# Altium schematic sheet SD2_and_Intentional_State.SchDoc(SD2_and_Intentional_State)
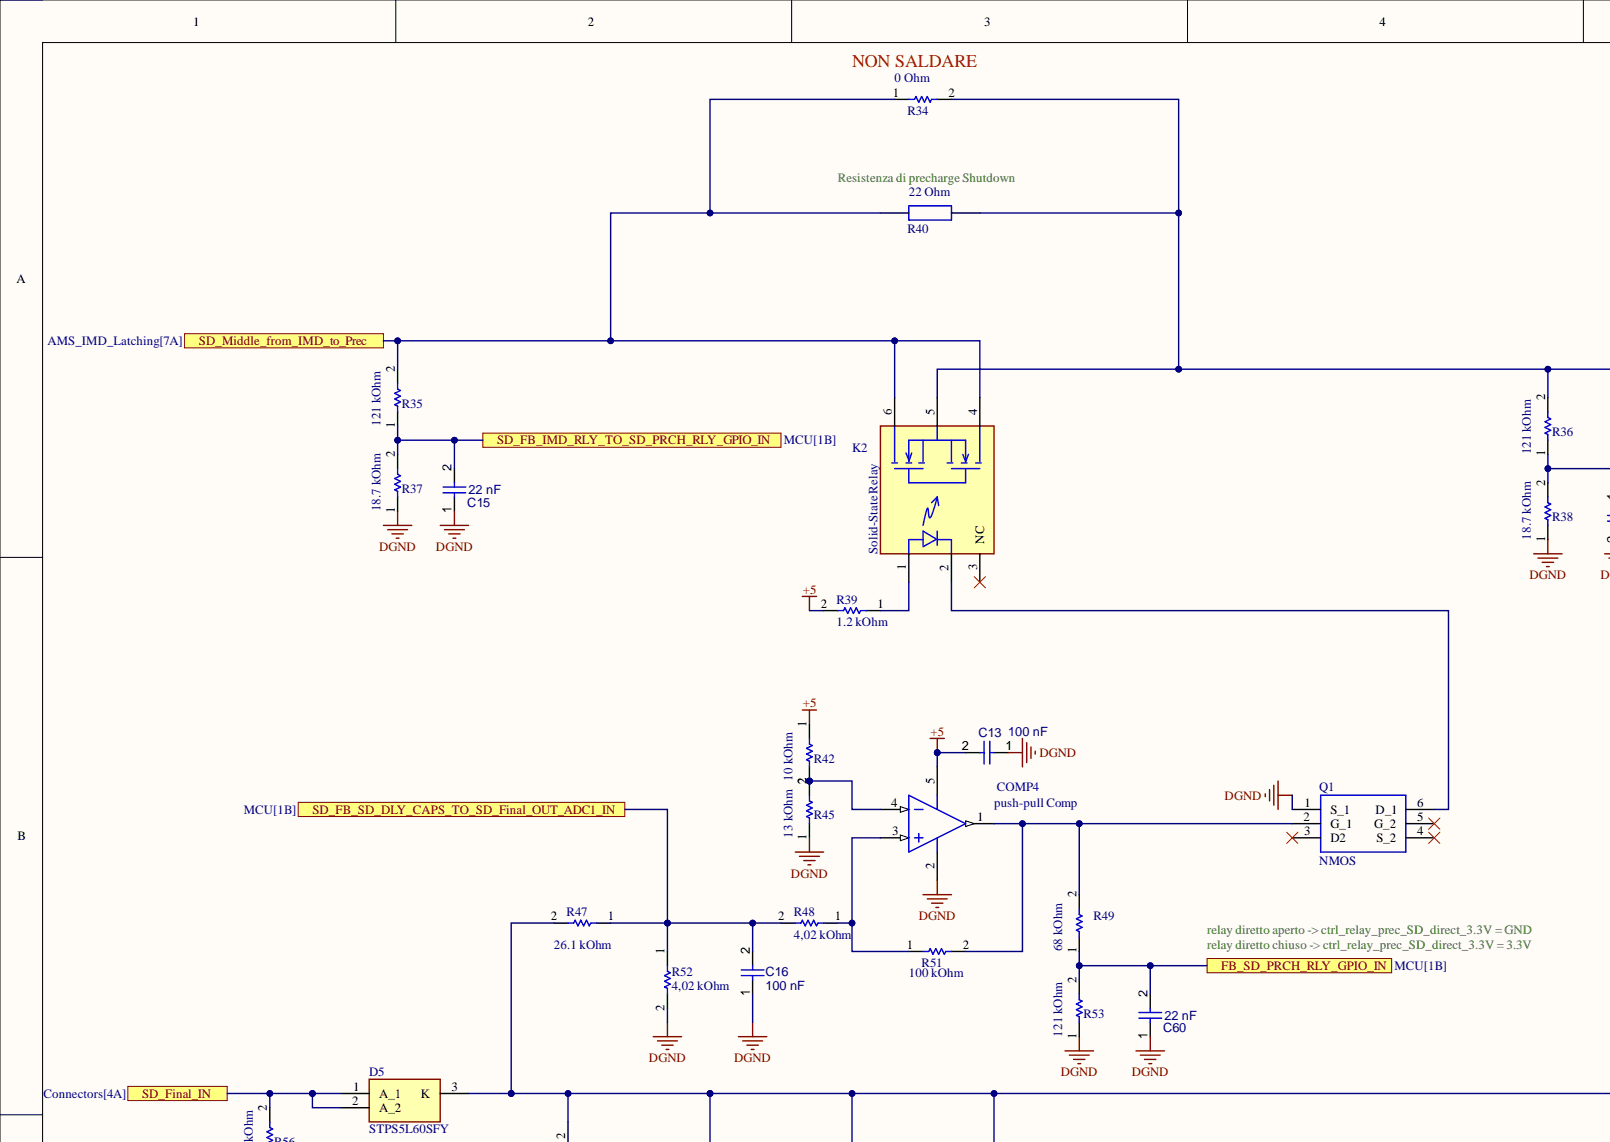
[diagram p0: SD2_and_Intentional_State.SchDoc, top left]
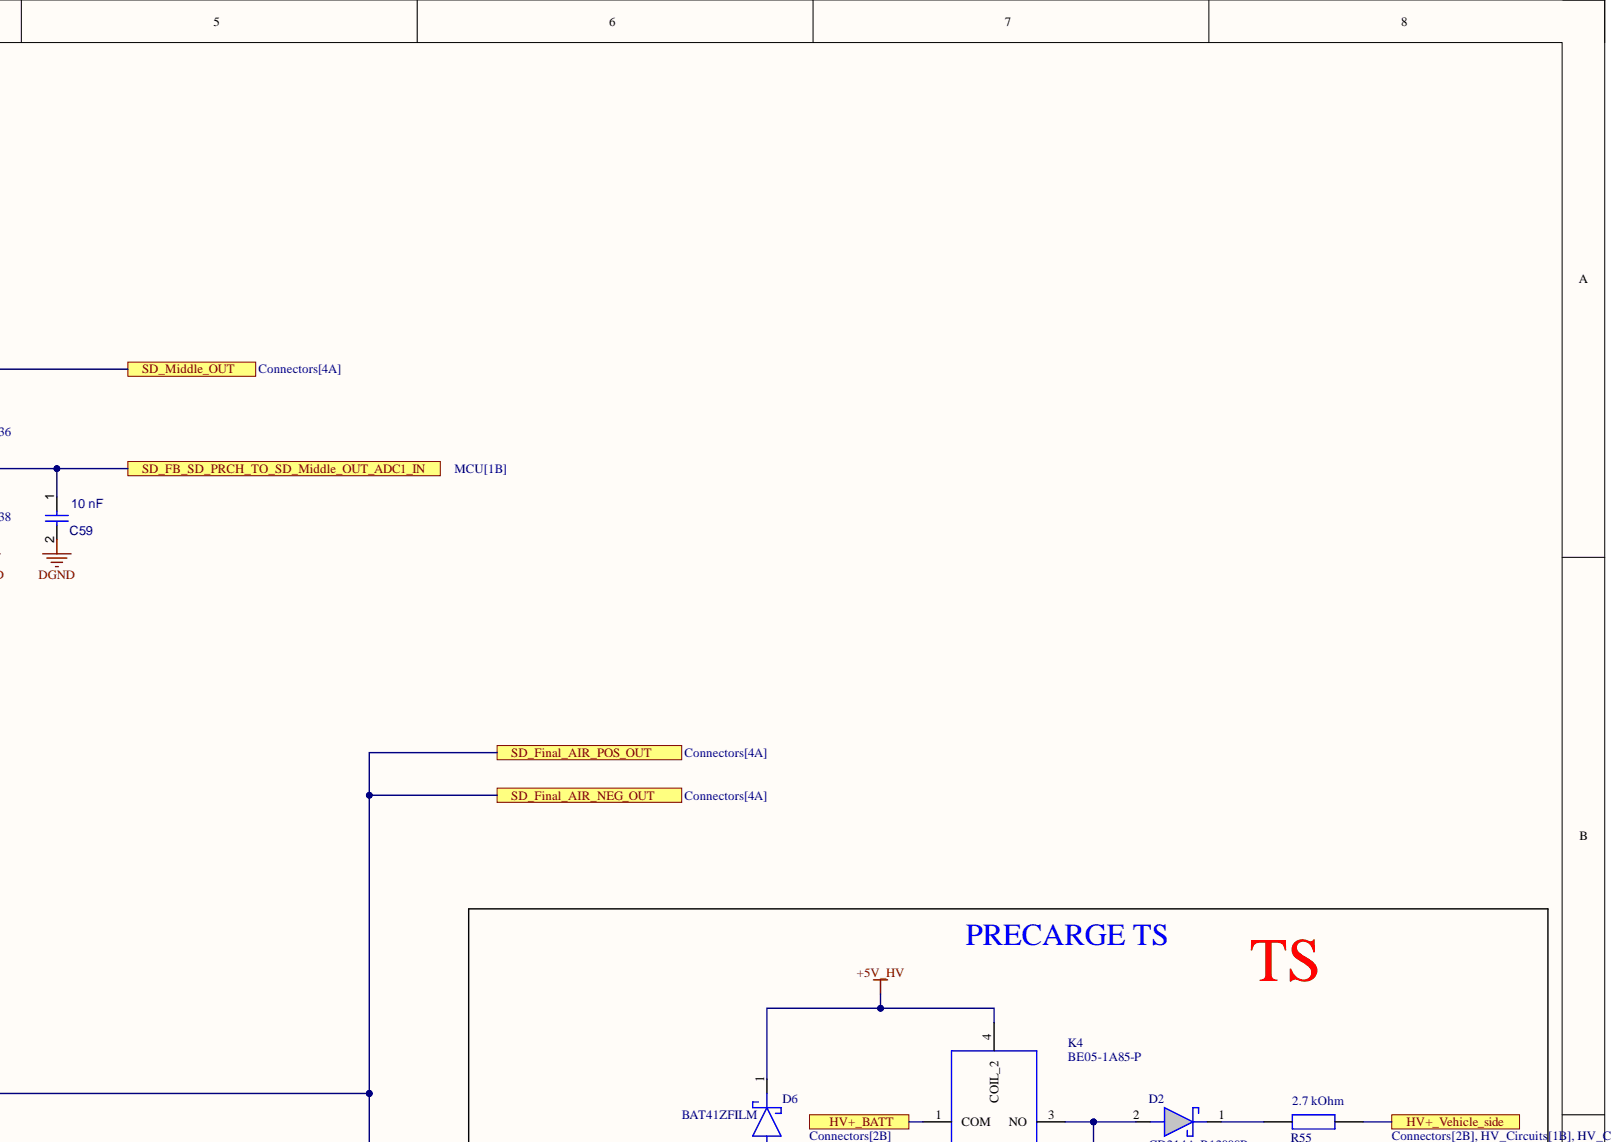
[diagram p1: SD2_and_Intentional_State.SchDoc, top right]
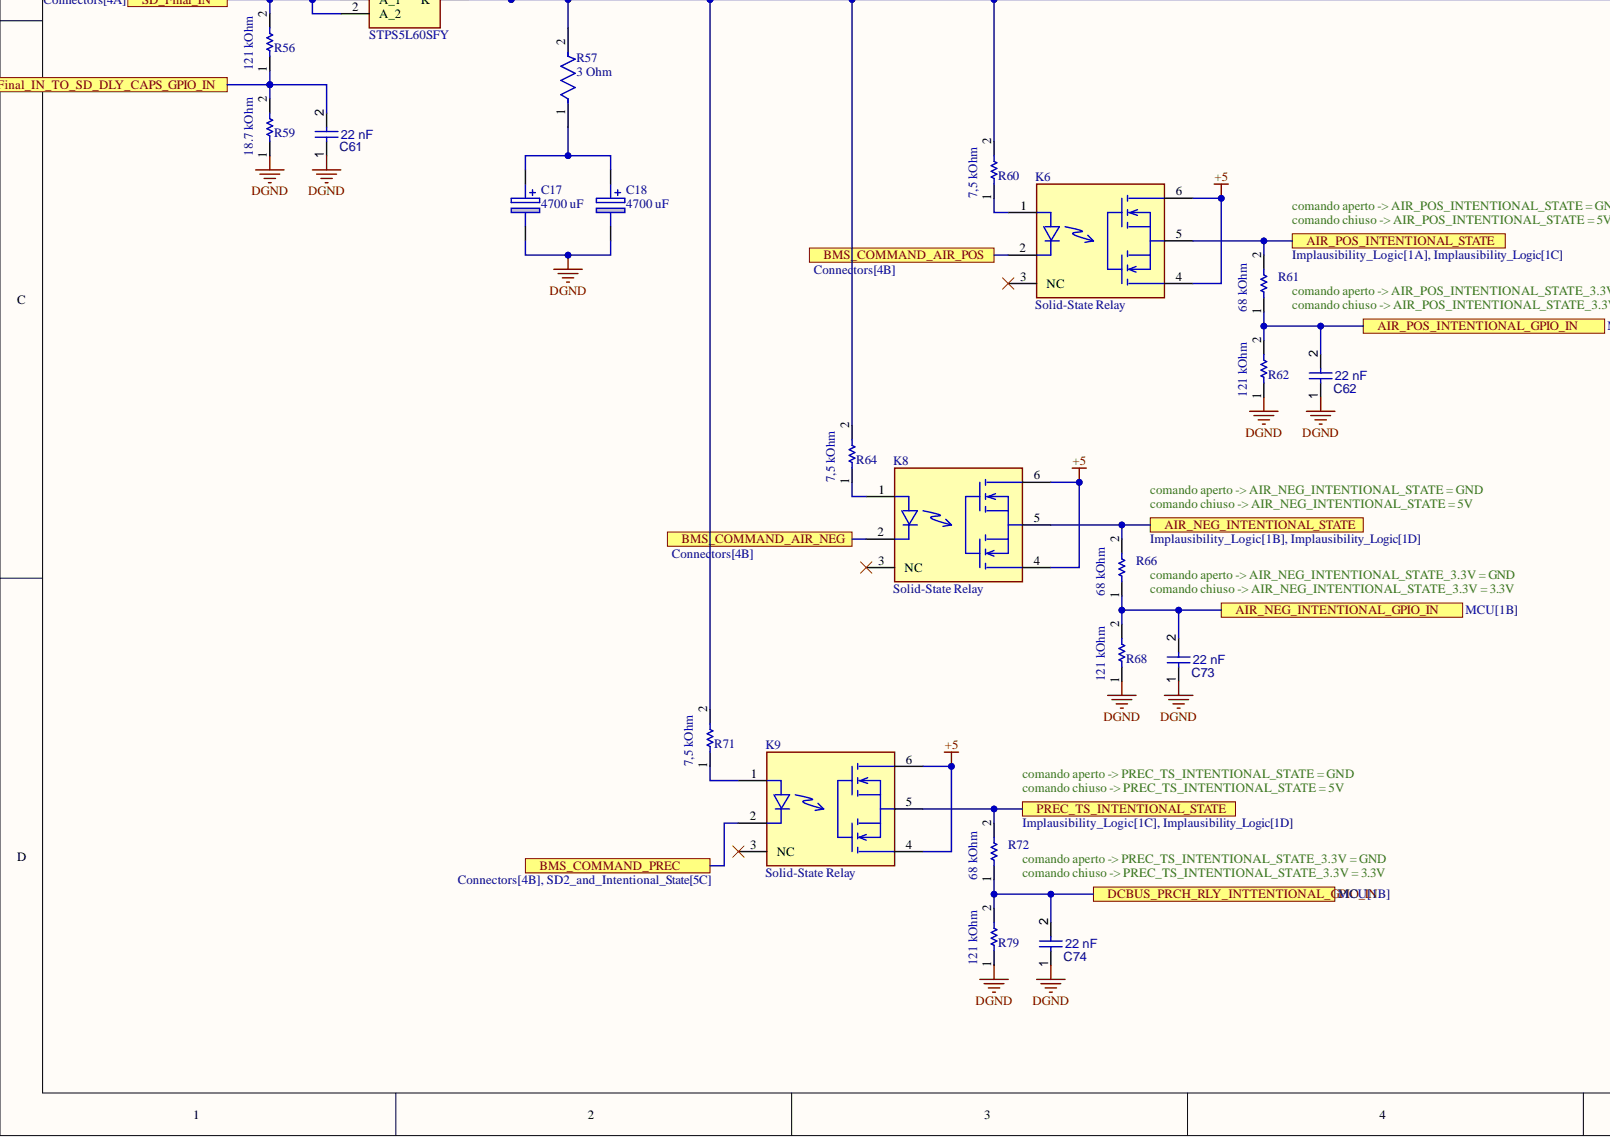
[diagram p2: SD2_and_Intentional_State.SchDoc, bottom left]
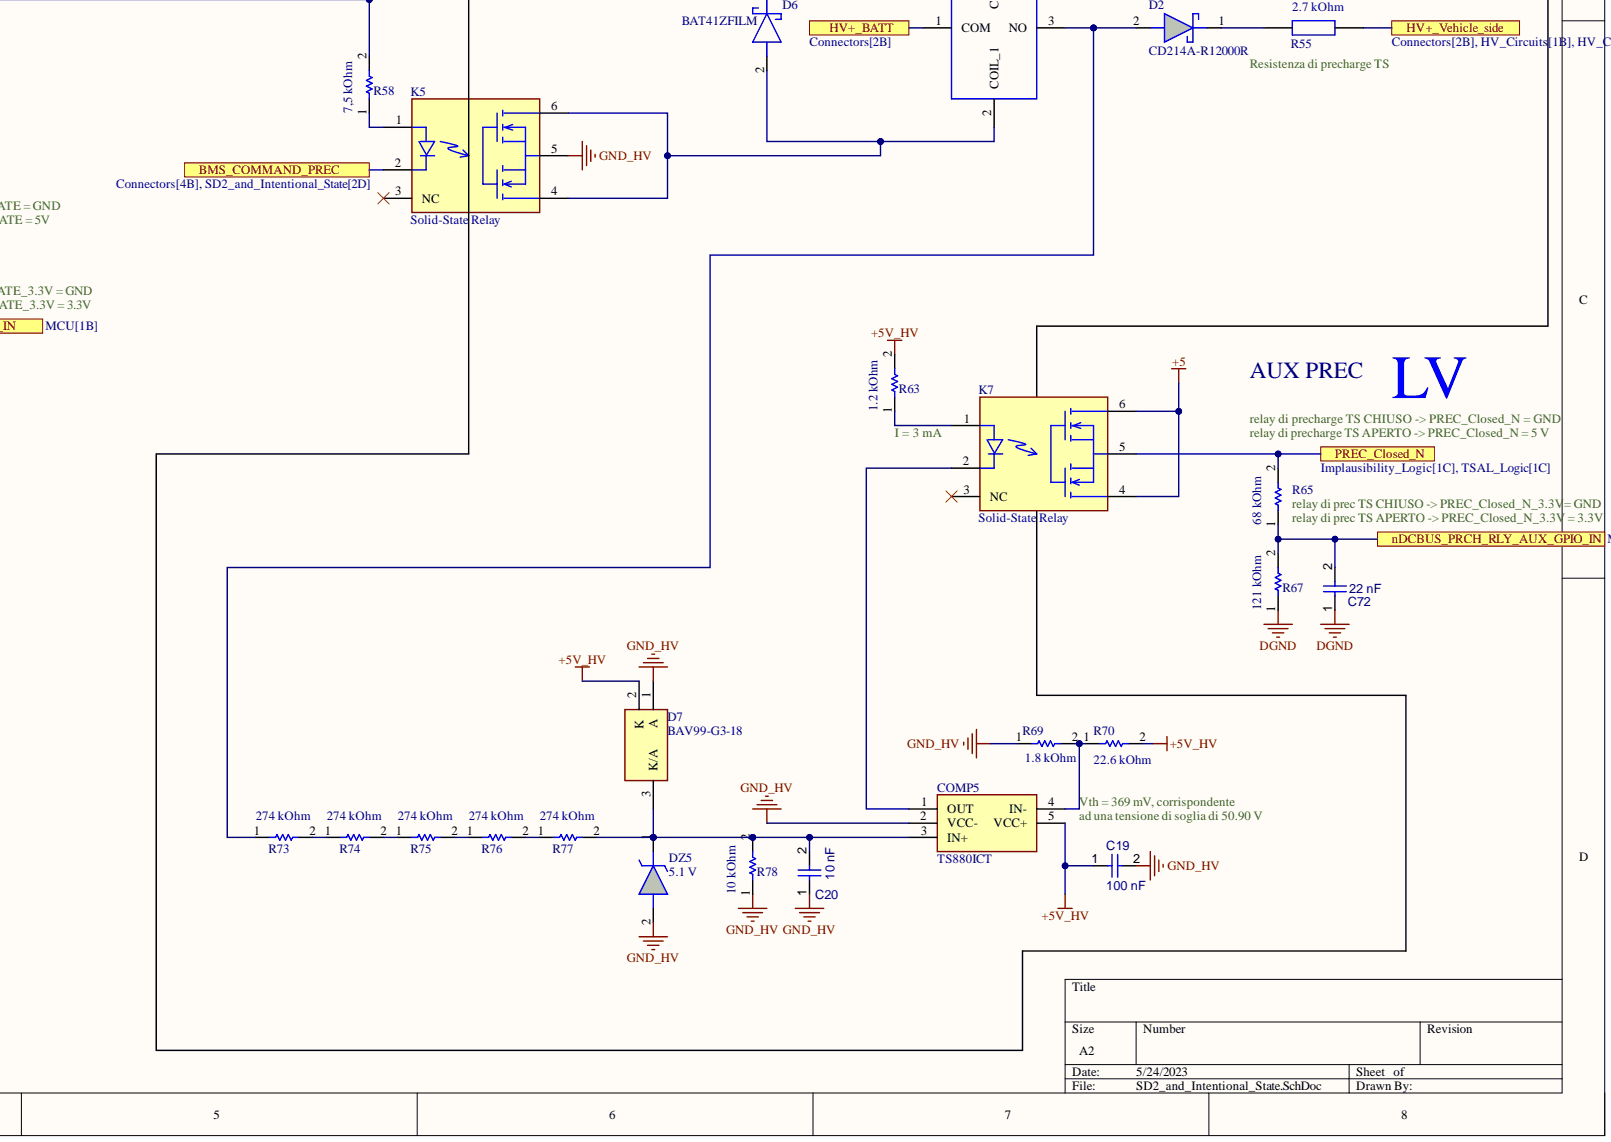
[diagram p3: SD2_and_Intentional_State.SchDoc, bottom right]

## Parts
C13  100 nF
C15  22 nF
C16  100 nF
C17  4700 uF
C18  4700 uF
C19  100 nF
C20  10 nF
C59  10 nF
C60  22 nF
C61  22 nF
C62  22 nF
C72  22 nF
C73  22 nF
C74  22 nF
COMP4  push-pull Comp
COMP5  TS880ICT
D2  CD214A-R12000R
D5  STPS5L60SFY
D6  BAT41ZFILM
D7  BAV99-G3-18
DZ5  5.1 V
K2  Solid-State Relay  Category: Relay
K4  BE05-1A85-P
K5  Solid-State Relay  Category: Relay
K6  Solid-State Relay  Category: Relay
K7  Solid-State Relay  Category: Relay
K8  Solid-State Relay  Category: Relay
K9  Solid-State Relay  Category: Relay
Q1  NMOS
R34  0 Ohm
R35  121 kOhm
R36  121 kOhm
R37  18.7 kOhm
R38  18.7 kOhm
R39  1.2 kOhm
R40  22 Ohm
R42  10 kOhm
R45  13 kOhm
R47  26.1 kOhm
R48  4,02 kOhm
R49  68 kOhm
R51  100 kOhm
R52  4,02 kOhm
R53  121 kOhm
R55  2.7 kOhm
R56  121 kOhm
R57  3 Ohm
R58  7,5 kOhm
R59  18.7 kOhm
R60  7,5 kOhm
R61  68 kOhm
R62  121 kOhm
R63  1.2 kOhm
R64  7,5 kOhm
R65  68 kOhm
R66  68 kOhm
R67  121 kOhm
R68  121 kOhm
R69  1.8 kOhm
R70  22.6 kOhm
R71  7,5 kOhm
R72  68 kOhm
R73  274 kOhm
R74  274 kOhm
R75  274 kOhm
R76  274 kOhm
R77  274 kOhm
R78  10 kOhm
R79  121 kOhm

## Ports
AIR_NEG_INTENTIONAL_GPIO_IN, AIR_NEG_INTENTIONAL_STATE, AIR_POS_INTENTIONAL_GPIO_IN, AIR_POS_INTENTIONAL_STATE, BMS_COMMAND_AIR_NEG, BMS_COMMAND_AIR_POS, BMS_COMMAND_PREC, DCBUS_PRCH_RLY_INTTENTIONAL_GPIO_IN, FB_SD_PRCH_RLY_GPIO_IN, HV+_BATT, HV+_Vehicle_side, nDCBUS_PRCH_RLY_AUX_GPIO_IN, PREC_Closed_N, PREC_TS_INTENTIONAL_STATE, SD_FB_IMD_RLY_TO_SD_PRCH_RLY_GPIO_IN, SD_FB_SD_DLY_CAPS_TO_SD_Final_OUT_ADC1_IN, SD_FB_SD_Final_IN_TO_SD_DLY_CAPS_GPIO_IN, SD_FB_SD_PRCH_TO_SD_Middle_OUT_ADC1_IN, SD_Final_AIR_NEG_OUT, SD_Final_AIR_POS_OUT, SD_Final_IN, SD_Middle_from_IMD_to_Prec, SD_Middle_OUT

## Nets
+5: C13.2 COMP4.5 K6.4 K6.6 K7.4 K7.6 K8.4 K8.6 K9.4 K9.6 R39.2 R42.1
+5V_HV: C19.1 COMP5.5(VCC+) D6.1 D7.2 K4.4(COIL_2) R63.2 R70.2
DGND: C13.1 C15.1 C16.1 C17.2 C18.2 C59.2 C60.1 C61.1 C62.1 C72.1 C73.1 C74.1 COMP4.2 Q1.1(S_1) R37.1 R38.1 R45.1 R52.2 R53.1 R59.1 R62.1 R67.1 R68.1 R79.1
GND_HV: C19.2 C20.1 COMP5.2(VCC-) D7.1 DZ5.2 K5.5 R69.1 R78.1
AIR_NEG_INTENTIONAL_GPIO_IN: C73.2 R66.1 R68.2 ; port AIR_NEG_INTENTIONAL_GPIO_IN
AIR_NEG_INTENTIONAL_STATE: K8.5 R66.2 ; port AIR_NEG_INTENTIONAL_STATE
AIR_POS_INTENTIONAL_GPIO_IN: C62.2 R61.1 R62.2 ; port AIR_POS_INTENTIONAL_GPIO_IN
AIR_POS_INTENTIONAL_STATE: K6.5 R61.2 ; port AIR_POS_INTENTIONAL_STATE
BMS_COMMAND_AIR_NEG: K8.2 ; port BMS_COMMAND_AIR_NEG
BMS_COMMAND_AIR_POS: K6.2 ; port BMS_COMMAND_AIR_POS
BMS_COMMAND_PREC: K5.2 K9.2 ; port BMS_COMMAND_PREC
DCBUS_PRCH_RLY_INTTENTIONAL_GPIO_IN: C74.2 R72.1 R79.2 ; port DCBUS_PRCH_RLY_INTTENTIONAL_GPIO_IN
FB_SD_PRCH_RLY_GPIO_IN: C60.2 R49.1 R53.2 ; port FB_SD_PRCH_RLY_GPIO_IN
HV+_BATT: K4.1(COM) ; port HV+_BATT
HV+_Vehicle_side: R55.2 ; port HV+_Vehicle_side
nDCBUS_PRCH_RLY_AUX_GPIO_IN: C72.2 R65.1 R67.2 ; port nDCBUS_PRCH_RLY_AUX_GPIO_IN
PREC_Closed_N: K7.5 R65.2 ; port PREC_Closed_N
PREC_TS_INTENTIONAL_STATE: K9.5 R72.2 ; port PREC_TS_INTENTIONAL_STATE
SD_FB_IMD_RLY_TO_SD_PRCH_RLY_GPIO_IN: C15.2 R35.1 R37.2 ; port SD_FB_IMD_RLY_TO_SD_PRCH_RLY_GPIO_IN
SD_FB_SD_DLY_CAPS_TO_SD_Final_OUT_ADC1_IN: C16.2 R47.1 R48.2 R52.1 ; port SD_FB_SD_DLY_CAPS_TO_SD_Final_OUT_ADC1_IN
SD_FB_SD_Final_IN_TO_SD_DLY_CAPS_GPIO_IN: C61.2 R56.1 R59.2 ; port SD_FB_SD_Final_IN_TO_SD_DLY_CAPS_GPIO_IN
SD_FB_SD_PRCH_TO_SD_Middle_OUT_ADC1_IN: C59.1 R36.1 R38.2 ; port SD_FB_SD_PRCH_TO_SD_Middle_OUT_ADC1_IN
SD_Final_AIR_NEG_OUT: D5.3 R47.2 R57.2 R58.2 R60.2 R64.2 R71.2 ; port SD_Final_AIR_NEG_OUT, port SD_Final_AIR_POS_OUT
SD_Final_IN: D5.1 D5.2 R56.2 ; port SD_Final_IN
SD_Middle_from_IMD_to_Prec: K2.4 K2.6 R34.1 R35.2 R40.1 ; port SD_Middle_from_IMD_to_Prec
SD_Middle_OUT: K2.5 R34.2 R36.2 R40.2 ; port SD_Middle_OUT
NetC17_1: C17.1 C18.1 R57.1
NetC20_2: C20.2 COMP5.3(IN+) D7.3 DZ5.1 R77.2 R78.2
NetCOMP4_1: COMP4.1 Q1.2(G_1) R49.2 R51.2
NetCOMP4_3: COMP4.3 R48.1 R51.1
NetCOMP4_4: COMP4.4 R42.2 R45.2
NetCOMP5_1: COMP5.1(OUT) K7.2
NetCOMP5_4: COMP5.4(IN-) R69.2 R70.1
NetD2_1: D2.1 R55.1
NetD2_2: D2.2 K4.3(NO) R73.1
NetD6_2: D6.2 K4.2(COIL_1) K5.4 K5.6
NetK2_1: K2.1 R39.1
NetK2_2: K2.2 Q1.6(D_1)
NetK5_1: K5.1 R58.1
NetK6_1: K6.1 R60.1
NetK7_1: K7.1 R63.1
NetK8_1: K8.1 R64.1
NetK9_1: K9.1 R71.1
NetR73_2: R73.2 R74.1
NetR74_2: R74.2 R75.1
NetR75_2: R75.2 R76.1
NetR76_2: R76.2 R77.1

Not connected: K2 3(NC); K5 3(NC); K6 3(NC); K7 3(NC); K8 3(NC); K9 3(NC); Q1 3(D2) 4(S_2) 5(G_2)

## Library data of the parts
C13 C16 C19 = CMP-001-00085-2: VJ0805Y104MXATW1BC; Tech: SMD; Component Kind: Standard; ComponentLink1Description: Website; Package: 0805; Library Name: EMEA- Squadra Corse PoliTO-  Politecnico di Torino
  C13 C16: ComponentLink1URL: https://www.mouser.it/ProductDetail/KYOCERA-AVX/08055C104KAT4A?qs=2ujN4bDBNE8aFT7CFyBStg%3D%3D
C15 C60 C61 C72-C74 = CMP-001-00085-2: VJ0805Y223JXACW1BC; Tech: SMD; Component Kind: Standard; ComponentLink1Description: Website; ComponentLink1URL: https://www.mouser.it/ProductDetail/Vishay-Vitramon/VJ0805Y223JXXPW1BC?qs=dYENeDlLSFWEZ4cdRF5phA%3D%3D; Package: 0805; Library Name: EMEA- Squadra Corse PoliTO-  Politecnico di Torino
C17 C18 = CMP-002-000013-1: MAL203860472E3; Manufacturer_Name: Vishay; Geometry.Height: 37.5mm; Tech: THT; Component Kind: Standard; ComponentLink1Description: Website; ComponentLink1URL: https://www.mouser.it/ProductDetail/Vishay-BC-Components/MAL203860472E3?qs=G99oyVYclWW%252Bmo91cUXlUQ%3D%3D; Datasheet Link: https://www.vishay.com/docs/28309/038rsu.pdf; Design Item ID: MAL203860472E3; Manufacturer_Part_Number: MAL203860472E3; Source: MAL203860472E3.SchLib; Library Name: EMEA- Squadra Corse PoliTO-  Politecnico di Torino
C20 C59 = CMP-001-00085-2: VJ0805H103KXBBC31; Tech: SMD; Component Kind: Standard; ComponentLink1Description: Website; Package: 0805; Library Name: EMEA- Squadra Corse PoliTO-  Politecnico di Torino
  C59: ComponentLink1URL: https://www.mouser.it/ProductDetail/Vishay-Vitramon/VJ0805H103KXBBC31?qs=ak4kh1ihuH4MS2NjAUlroQ%3D%3D
C62 = CMP-001-00085-2: VJ0805Y223JXXPW1BC; Tech: SMD; Component Kind: Standard; ComponentLink1Description: Website; ComponentLink1URL: https://www.mouser.it/ProductDetail/Vishay-Vitramon/VJ0805Y223JXXPW1BC?qs=dYENeDlLSFWEZ4cdRF5phA%3D%3D; Package: 0805; Library Name: EMEA- Squadra Corse PoliTO-  Politecnico di Torino
COMP4 = CMP-2000-07318-1: TS3021ICT; Manufacturer: STMicroelectronics; Common Mode Rejection Ratio: 79dB; Dual Supply Voltage (Max): NotRequiredV; Input Bias Current (Typ): 160nA; Input Offset Voltage: 6mV; Number of  Elements: 1; Power Dissipation: 600W; Power Supply Rejection Ratio: 69dB; Power Supply Requirement: Single; Rad Hardened: No; Response Time: 40ns; Single Supply Voltage (Max): 5V; Single Supply Voltage (Min): 2V; Single Supply Voltage (Typ): 3V; Supply Current: 0.115mA; Component Kind: Standard; Datasheet URL: http://www.st.com/content/ccc/resource/technical/document/datasheet/f3/6b/5e/5b/d9/95/43/ee/CD00118313.pdf/files/CD00118313.pdf/jcr:content/translations/en.CD00118313.pdf; Datasheet Version: Rev. 8, 07/2016; Dual Supply Voltage (Min): NotRequiredV; Dual Supply Voltage (Typ): NotRequiredV; Library Name: Altium Content Vault; Manufacturer URL: http://www.st.com/; Mounting: SurfaceMount; Mounting Technology: SurfaceMount; Operating Temp Range: -40Cto125C; Operating Temperature Classification: Automotive; Output Type: Push-Pull; Package Description: 5-Pin Small Outline Transistor, Body 2 x 1.25 mm, Pitch 0.65 mm; Package Reference: SC70-5; Package Type: SC-70; Packaging: TapeandReel; Pin Count: 5; Supply Current (Max): 0.115mA; Technology: BiCMOS
COMP5 = CMP-030-000037-3: Rail-to-rail 0.9V nanopower; Tech: SMD; Component Kind: Standard; Package: SC-70-5; Library Name: EMEA- Squadra Corse PoliTO-  Politecnico di Torino
D2 = CMP-098-000000-2: Schottky Diodes & Rectifiers 1.0A 2000V SMA; Manufacturer_Name: Bourns; Geometry.Height: 1.16mm; Component Kind: Standard; Datasheet Link: https://www.bourns.com/docs/product-datasheets/CD214A-R12000R.pdf; Design Item ID: CD214A-R12000R; Manufacturer_Part_Number: CD214A-R12000R; Mouser Part Number: 652-CD214A-R12000R; Mouser Price/Stock: https://www.mouser.co.uk/ProductDetail/Bourns/CD214A-R12000R?qs=GBLSl2Akirt52tUa7ctYiQ%3D%3D; Source: CD214A-R12000R.SchLib; Library Name: EMEA- Squadra Corse PoliTO-  Politecnico di Torino
D5 = CMP-036-000018-2: STMICROELECTRONICS - STPS5L60SFY - Schottky Rectifier; Tech: SMD; Component Kind: Standard; Package: TO-277A-3; Library Name: EMEA- Squadra Corse PoliTO-  Politecnico di Torino
D6 = CMP-036-000017-2: Schottky; Tech: SMD; Component Kind: Standard; Package: SOD-123-2; Library Name: EMEA- Squadra Corse PoliTO-  Politecnico di Torino
D7 = CMP-004-00033-1: BAV Diode; Tech: SMD; Component Kind: Standard; Package: SOT-23; Library Name: EMEA- Squadra Corse PoliTO-  Politecnico di Torino
DZ5 = CMP-002-000008-1: MMSZ5231C-E3-08; Manufacturer_Name: Vishay; Geometry.Height: 1.35mm; Tech: SMD; Component Kind: Standard; ComponentLink1Description: Website; ComponentLink1URL: https://www.mouser.it/ProductDetail/Vishay-Semiconductors/MMSZ5231C-E3-08?qs=S660mHfF5o35EDHKMfkp8w%3D%3D; Datasheet Link: https://www.arrow.com/en/products/mmsz5231c-e3-08/vishay; Design Item ID: MMSZ5231C-E3-08; Manufacturer_Part_Number: MMSZ5231C-E3-08; Mouser Part Number: 78-MMSZ5231C-E3-08; Mouser Price/Stock: https://www.mouser.co.uk/ProductDetail/Vishay-Semiconductors/MMSZ5231C-E3-08?qs=S660mHfF5o35EDHKMfkp8w%3D%3D; Package: SOD-123-2; Source: MMSZ5231C-E3-08.SchLib; Library Name: EMEA- Squadra Corse PoliTO-  Politecnico di Torino
K2 K5-K9 = CMP-2000-07398-2: VO14642AABTR; Manufacturer: Vishay; Input Current: 50mA; Isolation Voltage: 5300Vrms; Max Junction Temp: +125°C; Max Power Dissipation: 330mW; Number Of Contacts: 1; On State Resistance: 0.25Ω; Output Current: 2A; Output Voltage: 60V; Packag: SMD DIP-6; Standoff Height: 0.05mm; Tech: SMD; Throw Configuration: SPST-NO; Ambient Temperature Range High: +85°C; Ambient Temperature Range Low: -55°C; Automotive: No; Component Kind: Standard; ComponentLink2Description: Datasheet; ComponentLink2URL: http://www.vishay.com/docs/81646/vo14642a.pdf; ComponentLink3Description: Website; ComponentLink3URL: https://www.mouser.it/ProductDetail/Vishay-Semiconductors/VO14642AABTR?qs=%2Fjqivxn91cdjDkQHAFlOvw%3D%3D; Device Class L1: Electromechanical; Device Class L2: Relays; Device Class L3: Solid State Relays; Digikey Description: SSR RELAY SPST-NO 2A 0-60V; Height: 4.25mm; Ipc Land Pattern Name: SOIC254P980X425-6; Lead Free: Yes; Library Name: Altium Content Vault; Manufacturer Part Number: VO14642AABTR; Mouser Description: Solid State Relays - PCB Mount Normally Open Form 1A 60V; Octopart: https://octopart.com/vo14642aabtr-vishay-42763680; Package: VO14642AABTR; RoHS: TRUE; Temperature: to +125°C; Temperature Range High: +125°C
K4 = CMP-002-000012-1: Reed Relays DPST-NO 1A 5VDC 140Ohm; Manufacturer_Name: Meder; Geometry.Height: 10mm; Tech: THT; Component Kind: Standard; ComponentLink1Description: Website; ComponentLink1URL: https://www.mouser.it/ProductDetail/MEDER-electronic-Standex/BE05-1A85-P?qs=7fAzB%252B%252BFLim92GUtCXIBfQ%3D%3D; Datasheet Link: https://componentsearchengine.com/Datasheets/1/BE05-1A85-P.pdf; Design Item ID: BE05-1A85-P; Manufacturer_Part_Number: BE05-1A85-P; Mouser Part Number: 876-BE05-1A85-P; Mouser Price/Stock: https://www.mouser.com/Search/Refine.aspx?Keyword=876-BE05-1A85-P; Source: BE05-1A85-P.SchLib; Library Name: EMEA- Squadra Corse PoliTO-  Politecnico di Torino
Q1 = CMP-069-000000-2: SI1926DL-T1-GE3; Tech: SMD; Component Kind: Standard; ComponentLink1Description: Website; ComponentLink1URL: https://www.mouser.it/ProductDetail/Vishay-Semiconductors/SI1926DL-T1-GE3?qs=FfLXECYddQSjYFoPhKd4yw%3D%3D; Package: SOT-363-6; Library Name: EMEA- Squadra Corse PoliTO-  Politecnico di Torino
R34 = CMP-009-00181-1: CRCW12060000Z0EC; Tech: SMD; Component Kind: Standard; ComponentLink1Description: Website; ComponentLink1URL: https://www.mouser.it/ProductDetail/Vishay-Dale/CRCW12060000Z0EC?qs=y5m63dMNjqsQ4AM4mRfukg%3D%3D; Package: 1206; Library Name: EMEA- Squadra Corse PoliTO-  Politecnico di Torino
R35 R36 R53 R56 R62 R67 R68 R79 = CMP-009-00179-4: CRCW0603121KFKEA; Tech: SMD; Component Kind: Standard; ComponentLink1Description: Website; ComponentLink1URL: https://www.mouser.it/ProductDetail/Vishay-Dale/CRCW0603121KFKEA?qs=SNwsiWikH8rxaNKbUsRIDA%3D%3D; Package: 0603; Library Name: EMEA- Squadra Corse PoliTO-  Politecnico di Torino
R37 R38 R59 = CMP-009-00179-4: CRCW060318K7FKEA; Tech: SMD; Component Kind: Standard; ComponentLink1Description: Website; ComponentLink1URL: https://www.mouser.it/ProductDetail/Vishay-Dale/CRCW060318K7FKEA?qs=9qnzKDpA3VA15sIAY%252B8syw%3D%3D; Package: 0603; Library Name: EMEA- Squadra Corse PoliTO-  Politecnico di Torino
R39 R63 = CMP-009-00179-4: CRCW06031K20FKEAHP; Tech: SMD; Component Kind: Standard; ComponentLink1Description: Website; ComponentLink1URL: https://www.mouser.it/ProductDetail/Vishay-Dale/CRCW06031K20FKEAHP?qs=40TFAHZMFveP9B2Fb67Ndg%3D%3D; Package: 0603; Library Name: EMEA- Squadra Corse PoliTO-  Politecnico di Torino
R40 = CMP-085-000000-2: CW00522R00JE73; Tech: THT; Component Kind: Standard; ComponentLink1Description: Website; ComponentLink1URL: https://www.digikey.it/it/products/detail/vishay-dale/CW00522R00JE73/1168868; Package: 25.4x9.7 mm; Library Name: EMEA- Squadra Corse PoliTO-  Politecnico di Torino
R42 R78 = CMP-009-00179-4: CRCW060310K0FKEAHP; Tech: SMD; Component Kind: Standard; ComponentLink1Description: Website; Package: 0603; Library Name: EMEA- Squadra Corse PoliTO-  Politecnico di Torino
  R42: ComponentLink1URL: https://www.mouser.it/ProductDetail/Vishay-Dale/TNPW060310K0BEEC?qs=FzhAKWsHbnBcHjtNFnpYzQ%3D%3D
  R78: ComponentLink1URL: https://www.mouser.it/ProductDetail/Vishay-Dale/CRCW060310K0FKEAHP?qs=FlFvgi4rxBwhwmNEaK0SzQ%3D%3D
R45 = CMP-009-00179-4: TNPW060313K0BEEA; Tech: SMD; Component Kind: Standard; ComponentLink1Description: Website; ComponentLink1URL: https://www.mouser.it/ProductDetail/Vishay-Dale/TNPW060313K0BEEA?qs=UyvxJcuXVAYaRxwY8rjYhg%3D%3D; Package: 0603; Library Name: EMEA- Squadra Corse PoliTO-  Politecnico di Torino
R47 = CMP-009-00179-4: CRCW060326K1FKEA; Tech: SMD; Component Kind: Standard; ComponentLink1Description: Website; ComponentLink1URL: https://www.mouser.it/ProductDetail/Vishay-Dale/CRCW060326K1FKEA?qs=sR6giHT4ruF0zCQH%2FbgDUw%3D%3D; Package: 0603; Library Name: EMEA- Squadra Corse PoliTO-  Politecnico di Torino
R48 R52 = CMP-009-00179-4: CRCW06034K02FKEA; Tech: SMD; Component Kind: Standard; ComponentLink1Description: Website; ComponentLink1URL: https://www.mouser.it/ProductDetail/Vishay-Dale/CRCW06034K02FKEA?qs=ePVmox3SMzYmoL%252BtsFsAuw%3D%3D; Package: 0603; Library Name: EMEA- Squadra Corse PoliTO-  Politecnico di Torino
R49 R61 R65 R66 R72 = CMP-009-00179-4: CRCW060368K0FKEAC; Tech: SMD; Component Kind: Standard; ComponentLink1Description: Website; ComponentLink1URL: https://www.mouser.it/ProductDetail/Vishay-Dale/CRCW060368K0FKEAC?qs=E3Y5ESvWgWOWm3dIamBggg%3D%3D; Package: 0603; Library Name: EMEA- Squadra Corse PoliTO-  Politecnico di Torino
R51 = CMP-009-00179-4: MCT06030C1003FPW00; Tech: SMD; Component Kind: Standard; ComponentLink1Description: Website; ComponentLink1URL: https://www.mouser.it/ProductDetail/Vishay-Beyschlag/MCT06030C1003FPW00?qs=hjSuqQECij%252Bd7VKYd94hPQ%3D%3D; Package: 0603; Library Name: EMEA- Squadra Corse PoliTO-  Politecnico di Torino
R55 = CMP-083-000000-2: CW0102K700JE73; Tech: THT; Component Kind: Standard; ComponentLink1Description: Website; ComponentLink1URL: https://www.mouser.it/ProductDetail/Vishay-Dale/CW0102K700JE73?qs=2cWZpLZ9ismZHs0S0BLETQ%3D%3D; Package: 47.6x9.5 mm; Library Name: EMEA- Squadra Corse PoliTO-  Politecnico di Torino
R57 = CMP-02407-010029-1: CRCW20103R00FKEFHP; Max Power Dissipation: 750mW; Resistance: 3R; Tech: SMD; Temperature Coefficient: 100ppm/°C; Tolerance: 1%; Voltage Rating (DC): 400V; Case Code (Imperial): 2010; Case Code (Metric): 5025; Case/Package: 2010; Component Kind: Standard; ComponentLink1Description: New link; ComponentLink1URL: CRCW20103R00FKEFHP; Composition: Thick Film; Features: Automotive AEC-Q200; Height: 0.028inch; Lead Free: Lead Free; Length: 0.197inch; Library Name: Altium Content Vault; Max Operating Temperature: 155°C; Min Operating Temperature: -55°C; Number of Terminations: 2; Package Quantity: 1; Packaging: Tape and Reel; Width: 0.098inch
R58 R60 R64 R71 = CMP-009-00179-4: CRCW06037K50FKEA; Tech: SMD; Component Kind: Standard; ComponentLink1Description: Website; ComponentLink1URL: https://www.mouser.it/ProductDetail/Vishay-Dale/CRCW06037K50FKEA?qs=TPnjyyNsHsY8pgTVd3JRHw%3D%3D; Package: 0603; Library Name: EMEA- Squadra Corse PoliTO-  Politecnico di Torino
R69 = CMP-009-00179-4: CRCW06031K80FKEA; Tech: SMD; Component Kind: Standard; ComponentLink1Description: Website; ComponentLink1URL: https://www.mouser.it/ProductDetail/Vishay-Dale/CRCW06031K80FKEB?qs=YAISaLHWueGPFaYhA2TJ3Q%3D%3D; Package: 0603; Library Name: EMEA- Squadra Corse PoliTO-  Politecnico di Torino
R70 = CMP-009-00179-4: CRCW060322K6FKEA; Tech: SMD; Component Kind: Standard; ComponentLink1Description: Website; ComponentLink1URL: https://www.mouser.it/ProductDetail/Vishay-Dale/CRCW060322K6FKEA?qs=t%2Fk8jExiEquDeLbZmAOpJg%3D%3D; Package: 0603; Library Name: EMEA- Squadra Corse PoliTO-  Politecnico di Torino
R73-R77 = CMP-009-00181-1: CRCW1206274KFKEA; Tech: SMD; Component Kind: Standard; ComponentLink1Description: Website; ComponentLink1URL: https://www.mouser.it/ProductDetail/Vishay-Dale/CRCW1206274KFKEA?qs=sGAEpiMZZMvdGkrng054tygjBeyq%2FOAOaemDMLvyeVM%3D; Package: 1206; Library Name: EMEA- Squadra Corse PoliTO-  Politecnico di Torino
